# Altium schematic sheet 10.ADS1115.SchDoc(10.ADS1115)
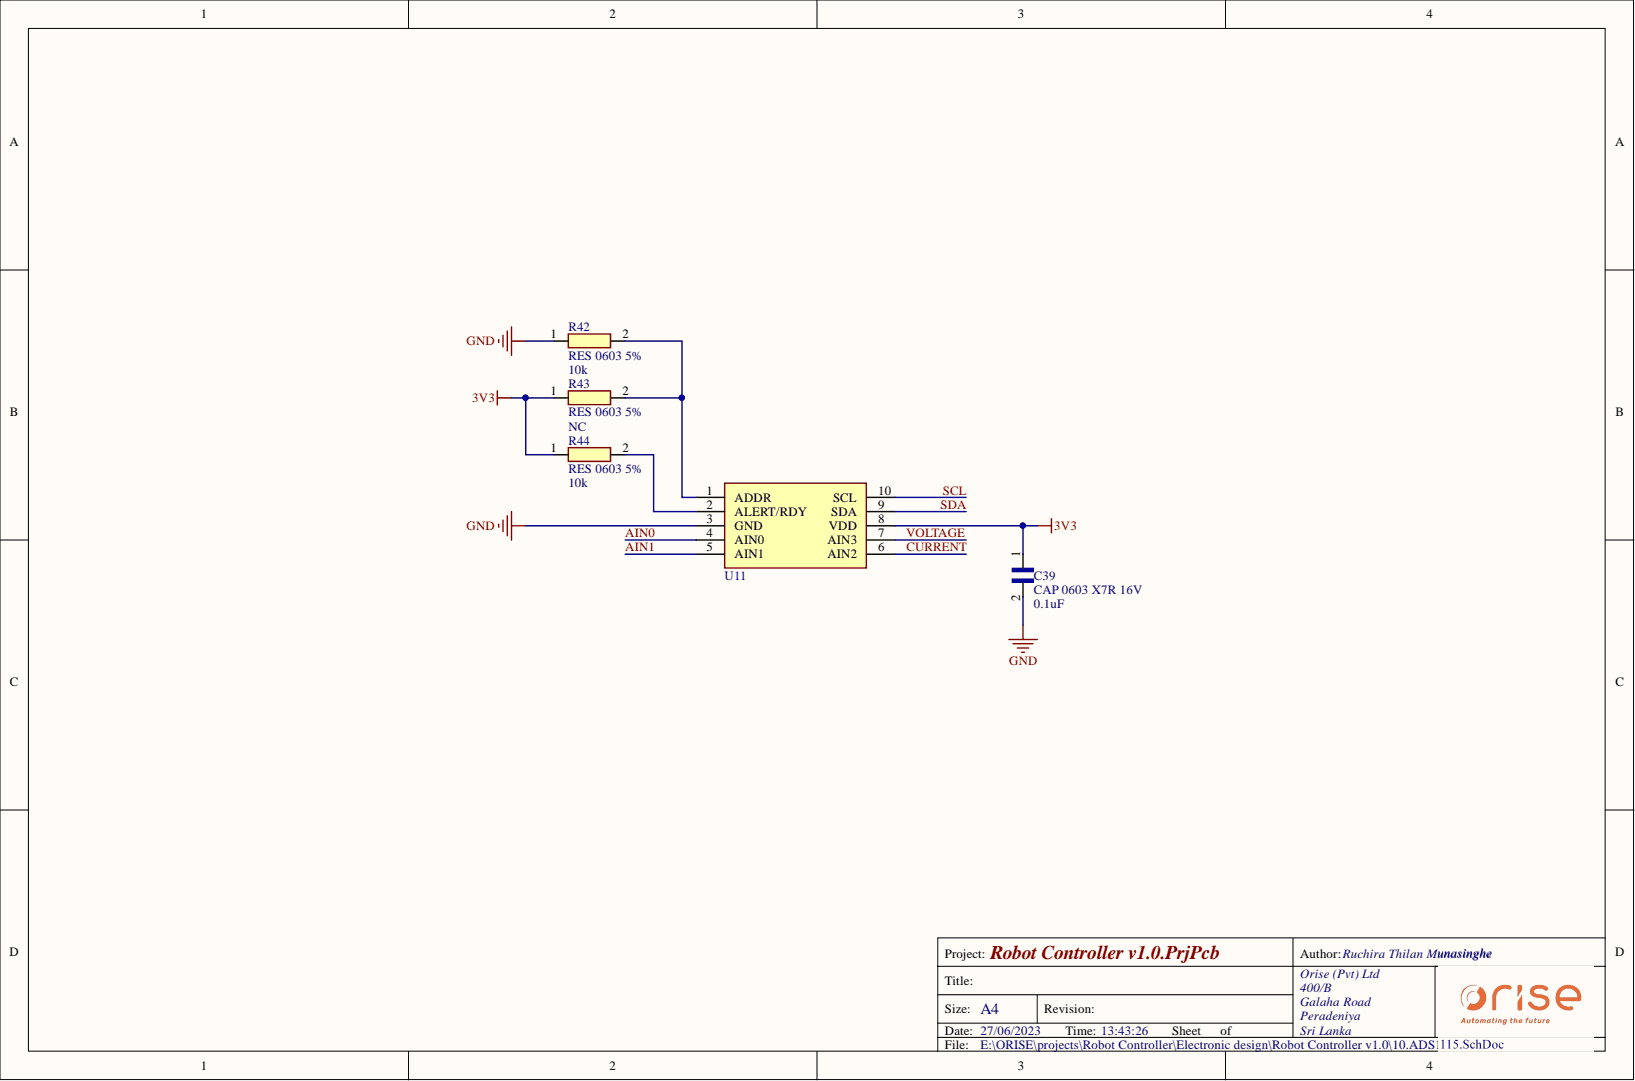
[diagram p0: 10.ADS1115.SchDoc]

## Parts
C39  CAP 0603 X7R 16V  value 0.1uF  [CAP 0603]
R42  RES 0603 5%  value 10k  [RES 0603]
R43  RES 0603 5%  value NC  [RES 0603 NC]
R44  RES 0603 5%  value 10k  [RES 0603]
U11  ADS1115  [ADS1115]  (value not drawn)

## Nets
3V3: C39.1 R43.1 R44.1 U11.8(VDD)
GND: C39.2 R42.1 U11.3(GND)
AIN0: U11.4(AIN0)
AIN1: U11.5(AIN1)
CURRENT: U11.6(AIN2)
SCL: U11.10(SCL)
SDA: U11.9(SDA)
VOLTAGE: U11.7(AIN3)
NetR42_2: R42.2 R43.2 U11.1(ADDR)
NetR44_2: R44.2 U11.2(ALERT/RDY)

## Library data of the parts
R42 = RES 0603 5%: value: 10k; Library Name: Robot Controller v1.0.SchLib; Description: RES 0603 5%; Component Kind: Standard
R43 = RES 0603 5% NC: value: NC; Library Name: Robot Controller v1.0.SchLib; Description: RES 0603 5%; Component Kind: Standard
U11 = ADS1115: Integrated Circuit; Library Name: Robot Controller v1.0.SchLib; Component Kind: Standard
C39 = CAP 0603 X7R 16V: Library Name: Robot Controller v1.0.SchLib; Description: CAP 0603 X7R 16V; Component Kind: Standard
R44 = RES 0603 5%: Library Name: Robot Controller v1.0.SchLib; Description: RES 0603 5%; Component Kind: Standard
